# Altium schematic sheet [18] - PS MGT.SchDoc("[18] - PS MGT")
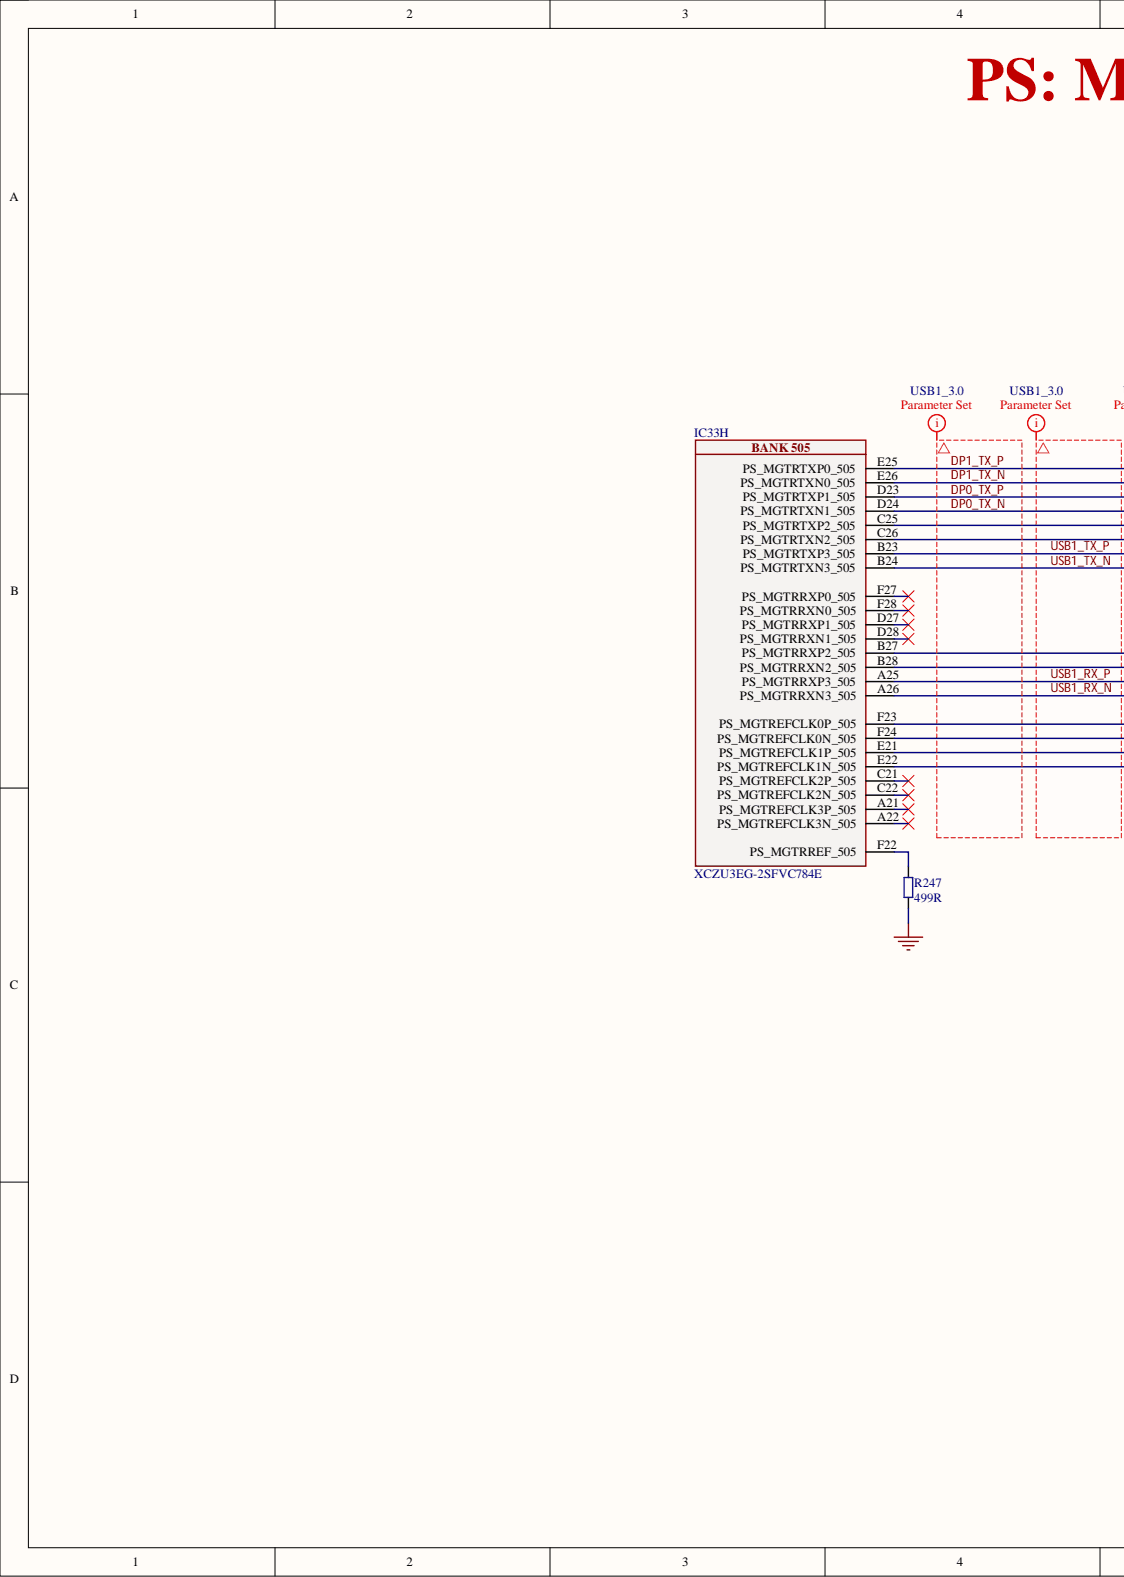
[diagram p0: [18] - PS MGT.SchDoc, left]
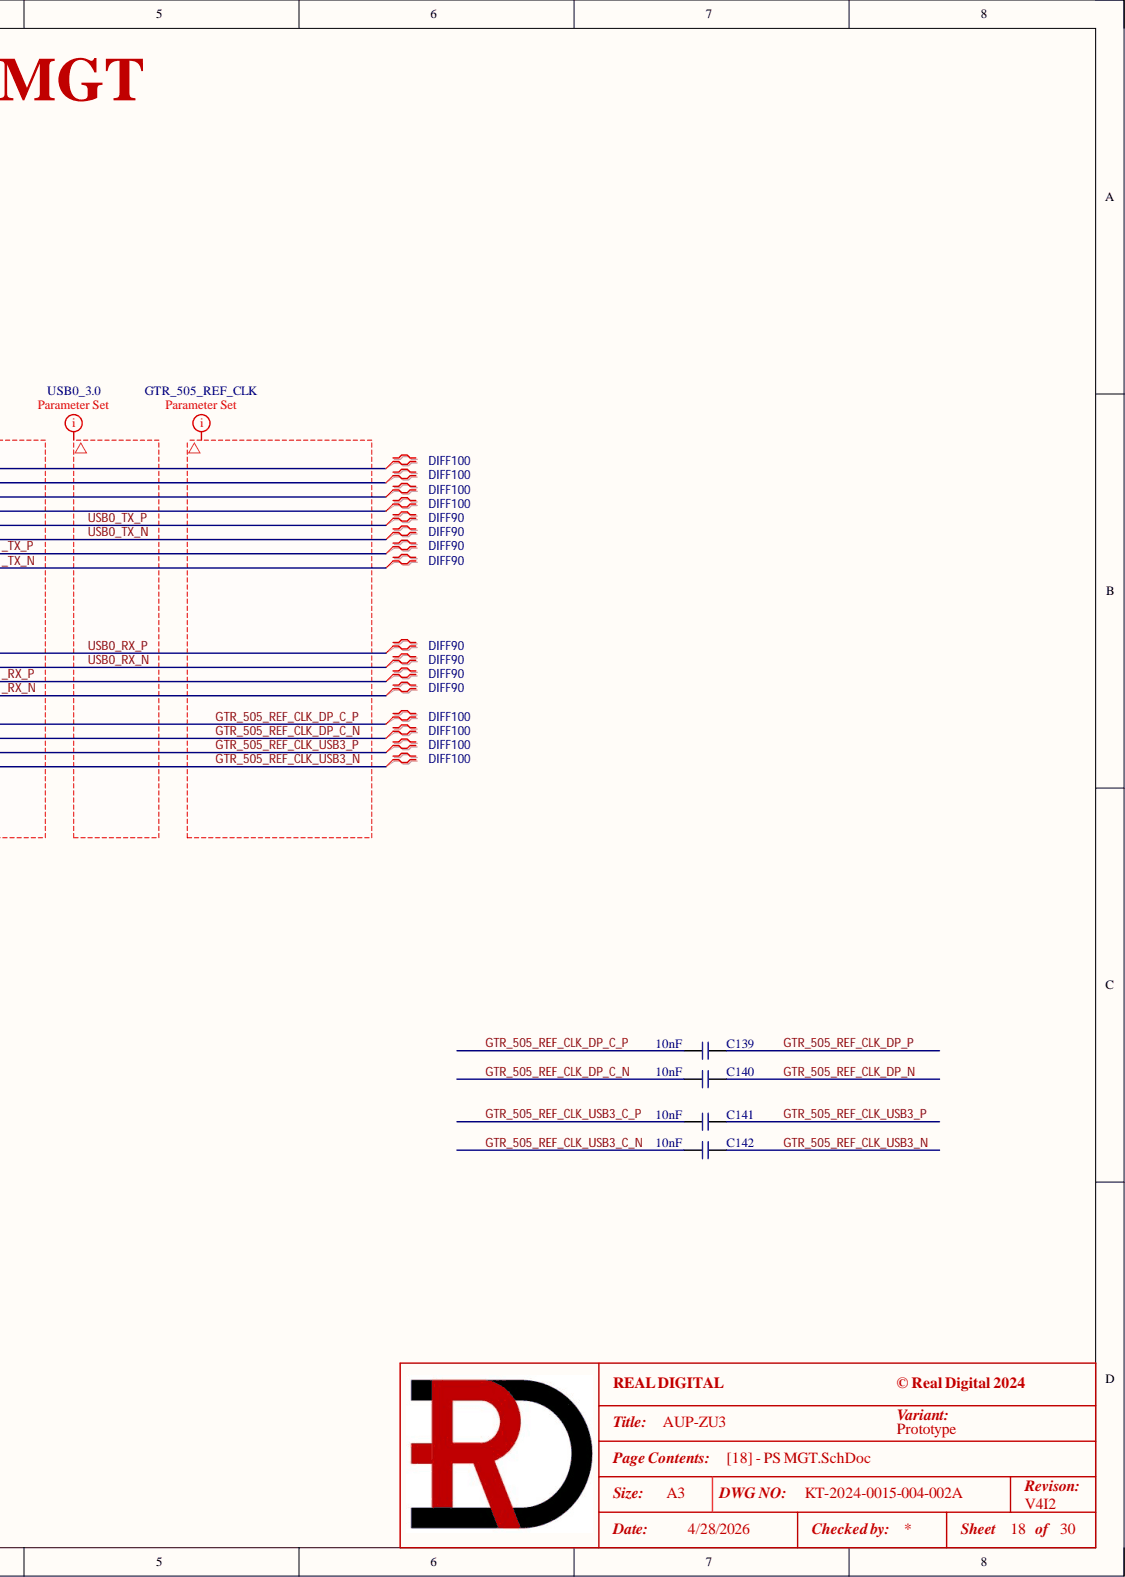
[diagram p1: [18] - PS MGT.SchDoc, right]

## Parts
C139  10nF  [C0201]
C140  10nF  [C0201]
C141  10nF  [C0201]
C142  10nF  [C0201]
IC33  XCZU3EG-2SFVC784E  [SFVC784 - AMD]  (part H)
R247  499R  [R0402]

## Nets
GND: R247.1
DP0_TX_N: IC33.D24(PS_MGTRTXN1_505)
DP0_TX_P: IC33.D23(PS_MGTRTXP1_505)
DP1_TX_N: IC33.E26(PS_MGTRTXN0_505)
DP1_TX_P: IC33.E25(PS_MGTRTXP0_505)
GTR_505_REF_CLK_DP_C_N: C140.1 IC33.F24(PS_MGTREFCLK0N_505)
GTR_505_REF_CLK_DP_C_P: C139.1 IC33.F23(PS_MGTREFCLK0P_505)
GTR_505_REF_CLK_DP_N: C140.2
GTR_505_REF_CLK_DP_P: C139.2
GTR_505_REF_CLK_USB3_C_N: C142.1
GTR_505_REF_CLK_USB3_C_P: C141.1
GTR_505_REF_CLK_USB3_N: C142.2 IC33.E22(PS_MGTREFCLK1N_505)
GTR_505_REF_CLK_USB3_P: C141.2 IC33.E21(PS_MGTREFCLK1P_505)
USB0_RX_N: IC33.B28(PS_MGTRRXN2_505)
USB0_RX_P: IC33.B27(PS_MGTRRXP2_505)
USB0_TX_N: IC33.C26(PS_MGTRTXN2_505)
USB0_TX_P: IC33.C25(PS_MGTRTXP2_505)
USB1_RX_N: IC33.A26(PS_MGTRRXN3_505)
USB1_RX_P: IC33.A25(PS_MGTRRXP3_505)
USB1_TX_N: IC33.B24(PS_MGTRTXN3_505)
USB1_TX_P: IC33.B23(PS_MGTRTXP3_505)
NetIC33_F22: IC33.F22(PS_MGTRREF_505) R247.2

Not connected: IC33 A21(PS_MGTREFCLK3P_505) A22(PS_MGTREFCLK3N_505) C21(PS_MGTREFCLK2P_505) C22(PS_MGTREFCLK2N_505) D27(PS_MGTRRXP1_505) D28(PS_MGTRRXN1_505) F27(PS_MGTRRXP0_505) F28(PS_MGTRRXN0_505)

## Library data of the parts
C139-C142 = GRM033R71E103KE14D: CAP CER 10000pF 25V X7R 0201; Manufacturer 1: Murata Electronics; .Checked_PCB: RV; C P/N: RD-111-0043; LoadStatus: Load; Tolerance: 10%; Category: Capacitors; Component Kind: Standard; Library Name: KT-RealDigital.IntLib; Manufacturer 1 Part Number: GRM033R71E103KE14D; Temperature Coefficient: X7R; Voltage - Rated: 25V; .Checked_SCH: RV; .Creator: RV
IC33 = XCZU3EG-2SFVC784E: Quad ARM® Cortex®-A53 MPCore™ with CoreSight™, Dual ARM®Cortex™-R5 with CoreSight™, ARM Mali™-400 MP2 System On Chip (SOC) IC Zynq® UltraScale+™ MPSoC EG Zynq®UltraScale+™ FPGA, 154K+ Logic Cells 533MHz, 600MHz, 1.3GHz 784-FCBGA (23x23); Manufacturer 1: AMD; .Checked_PCB: RV; C P/N: RD-126-0101-C; LoadStatus: Load; Category: IC; Component Kind: Standard; Library Name: KT-RealDigital.IntLib; Manufacturer 1 Part Number: XCZU3EG-2SFVC784E; .Checked_SCH: RV; .Creator: RV
R247 = RT0402BRE07499RL: RES SMD 499 OHM 0.1% 1/16W 0402; Manufacturer 1: Yageo; .Checked_PCB: RV; C P/N: RD-101-0038; LoadStatus: Load; Tolerance: 0.1%/TK50; Category: Resistors; Component Kind: Standard; Library Name: KT-RealDigital.IntLib; Manufacturer 1 Part Number: RT0402BRE07499RL; .Checked_SCH: RV; .Creator: RV
